# Altium schematic sheet [03] - SCHEMATIC.SchDoc
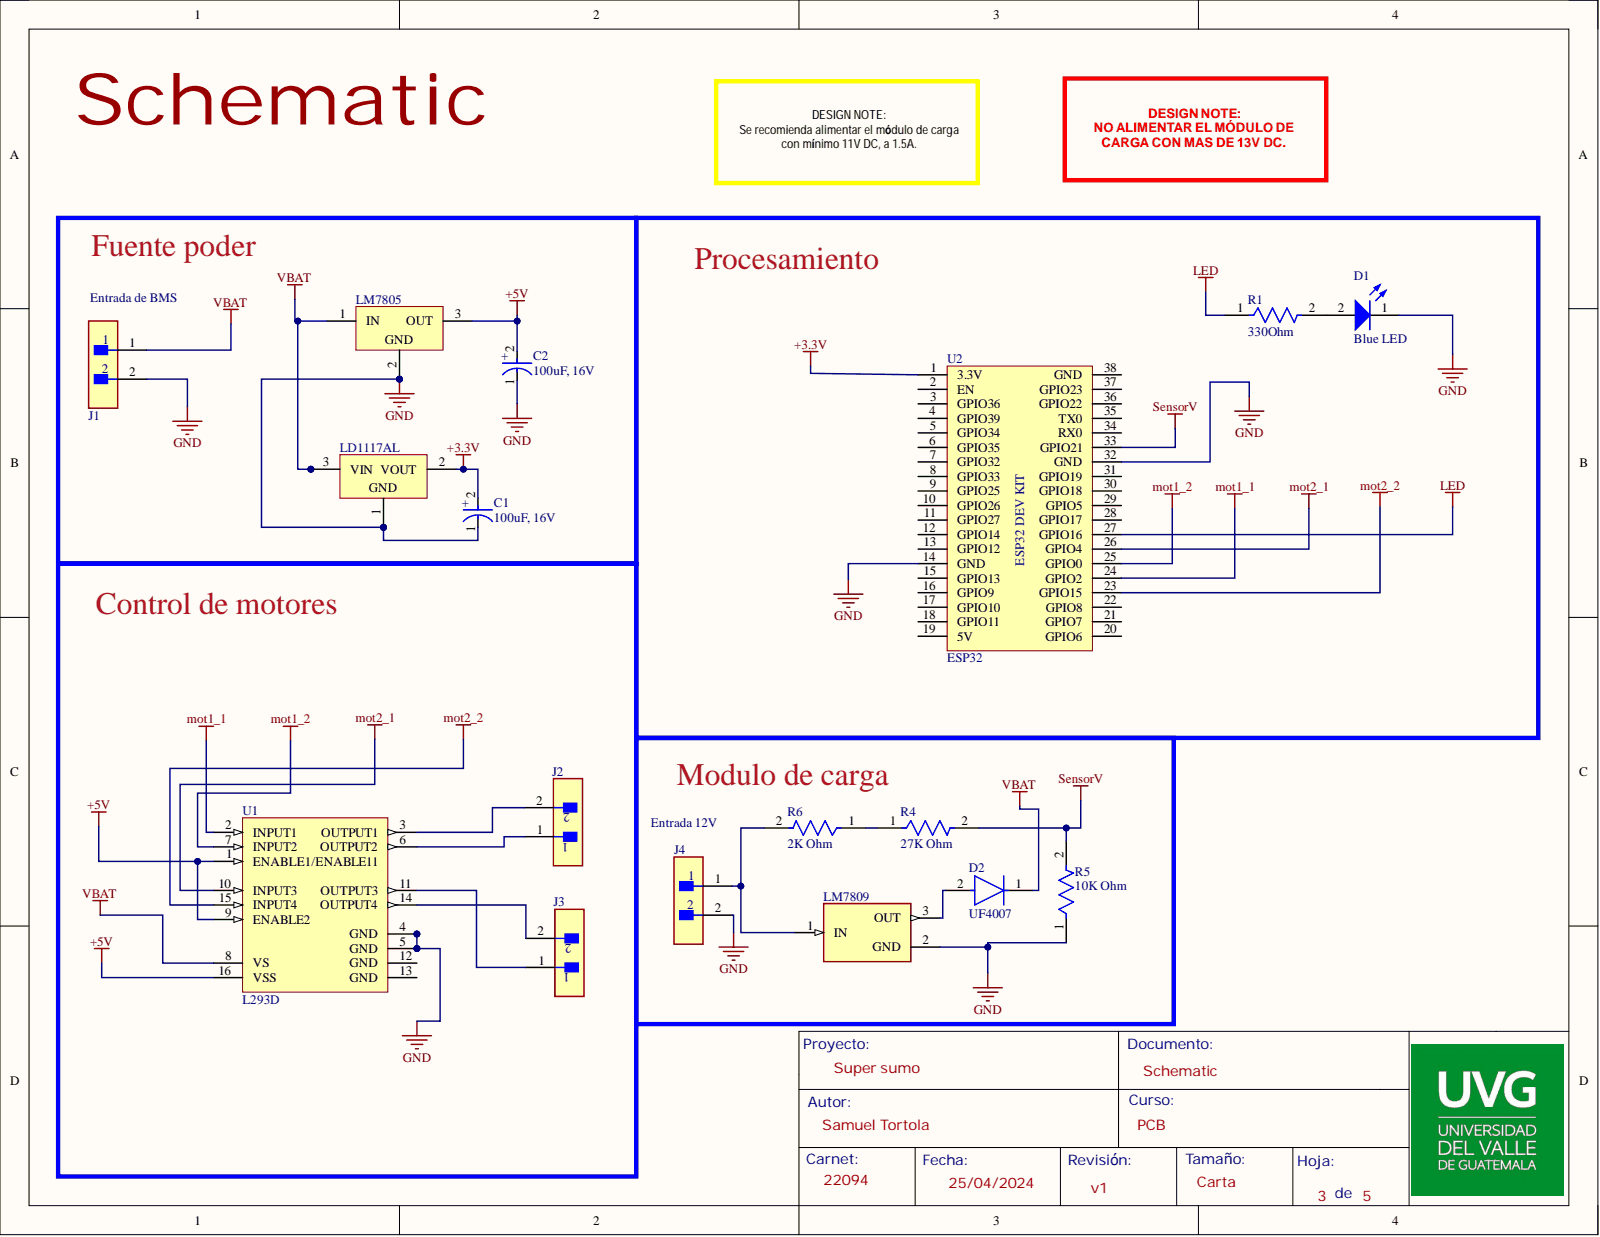
[diagram p0: [03] - SCHEMATIC.SchDoc]

## Parts
C1  100uF, 16V  [FP-RAD-TH-D_6_3_0_5-L_7_1-MFG]
C2  100uF, 16V  [FP-RAD-TH-D_6_3_0_5-L_7_1-MFG]
D1  Blue LED  Color: Blue  [WL-TMRW_D3.9H10.5]
D2  UF4007  [FP-017AH-MFG]
J1  B2B-EH-A(LF)(SN)  [FP-B2B-EH-A_LF_SN-MFG]  (value not drawn)
J2  B2B-EH-A(LF)(SN)  [FP-B2B-EH-A_LF_SN-MFG]  (value not drawn)
J3  B2B-EH-A(LF)(SN)  [FP-B2B-EH-A_LF_SN-MFG]  (value not drawn)
J4  B2B-EH-A(LF)(SN)  [FP-B2B-EH-A_LF_SN-MFG]  (value not drawn)
LD1117AL  LD1117V33C  [TO220]  (value not drawn)
LM7805  LM7805  [TO220]
LM7809  LM7809CT  [TO254P470X1016X1879-3P]  (value not drawn)
R1  330Ohm  [FP-MRS25-MFG]
R4  27K Ohm  [FP-MRS25-MFG]
R5  10K Ohm  [FP-MRS25-MFG]
R6  2K Ohm  [FP-MRS25-MFG]
U1  L293D  [STM-L293D-16]
U2  ESP32  [ESP32 DEV KIT]

## Nets
+3.3V: C1.2 LD1117AL.2 U2.1(3.3V)
+5V: C2.2 LM7805.3 U1.1(ENABLE1/ENABLE11) U1.9(ENABLE2) U1.16(VSS)
GND: C1.1 C2.1 D1.1 J1.2 J4.2 LD1117AL.1 LM7805.2 LM7809.2 R5.1 U1.4(GND) U1.5(GND) U2.14(GND) U2.32(GND)
LED: R1.1 U2.27(GPIO16)
mot1_1: U1.2(INPUT1) U2.24(GPIO2)
mot1_2: U1.7(INPUT2) U2.25(GPIO0)
mot2_1: U1.10(INPUT3) U2.26(GPIO4)
mot2_2: U1.15(INPUT4) U2.23(GPIO15)
SensorV: R4.2 R5.2 U2.33(GPIO21)
VBAT: D2.1 J1.1 LD1117AL.3 LM7805.1 U1.8(VS)
NetD1_2: D1.2 R1.2
NetD2_2: D2.2 LM7809.3
NetJ2_1: J2.1 U1.6(OUTPUT2)
NetJ2_2: J2.2 U1.3(OUTPUT1)
NetJ3_1: J3.1 U1.11(OUTPUT3)
NetJ3_2: J3.2 U1.14(OUTPUT4)
NetJ4_1: J4.1 LM7809.1 R6.2
NetR4_1: R4.1 R6.1

Not connected: U1 12(GND) 13(GND); U2 2(EN) 3(GPIO36) 4(GPIO39) 5(GPIO34) 6(GPIO35) 7(GPIO32) 8(GPIO33) 9(GPIO25) 10(GPIO26) 11(GPIO27) 12(GPIO14) 13(GPIO12) 15(GPIO13) 16(GPIO9) 17(GPIO10) 18(GPIO11) 19(5V) 20(GPIO6) 21(GPIO7) 22(GPIO8) 28(GPIO17) 29(GPIO5) 30(GPIO18) 31(GPIO19) 34(RX0) 35(TX0) 36(GPIO22) 37(GPIO23) 38(GND)

## Library data of the parts
C1 C2 = CMP-05427-001316-1: Aluminum Electrolytic Capacitors (Radial Lead Type) 100uF ±20% 16V; Lead Diameter: 0.45mm; Life (Hours): 1000Hour; Polarity: Polar; Ripple Current: 91mA; Capacitance: 100uF; Case/Package: Radial; Component Kind: Standard; Diameter: 6.3mm; Height: 7mm; Height - Seated (Max): 0.315inch; Lead Pitch: 2.5mm; Length: 7mm; Library Name: Altium Content Vault; Max Operating Temperature: 85°C; Min Operating Temperature: -40°C; Mount: Through Hole; Package Quantity: 2000; Packaging: Tape and Reel; Radiation Hardening: No; RoHS Compliant: Yes; Series: KA; Termination: Radial; Tolerance: 20%; Voltage: 16V; Voltage Rating: 16V; Voltage Rating (DC): 16V
D1 = CMP-1489-00002-2: NexarPartId: 29717808; Dominant Wavelength: 470nm; Forward Current: 100mA; Forward Voltage: 2.8V; Lens Size: 3mm; Lens Style: Circular, Clear, Round; Luminous Intensity: 3.8cd; Max Reverse Voltage (DC): 5V; Peak Wavelength: 465nm; Test Current: 20mA; Viewing Angle: 30°; Case/Package: Radial; Component Kind: Standard; Height: 5.3mm; Length: 3mm; Library Name: Altium Content Vault; Max Operating Temperature: 85°C; Min Operating Temperature: -40°C; Mount: Through Hole; Packaging: Bulk; REACH SVHC: Unknown; RoHS: Compliant; Wavelength: 470nm; Width: 3mm
D2 = CMP-2000-05028-2: DIODE GEN PURP 1KV 1A DO41; Manufacturer: ON Semiconductor; Capacitance: 17pF; Max Junction Temp: +150°C; Max Repetitive Reverse Voltage: 1000V; Max Surge Forward Current: 30A; Peak Reverse Current: 10uA; Value: 17pF; Automotive: No; Category: Diode; Component Kind: Standard; ComponentLink2Description: Datasheet; ComponentLink2URL: https://www.onsemi.com/pub/Collateral/UF4007-D.pdf; Device Class L1: Discrete Semiconductors; Device Class L2: Diodes; Device Class L3: Rectifier Diodes; Digikey Description: DIODE GEN PURP 1KV 1A DO41; Footprint Url: https://www.onsemi.com/pub/Collateral/017AH.PDF; Forward Current: 1A; Height: 2.72mm; Lead Free: Yes; Library Name: Altium Content Vault; Manufacturer Part Number: UF4007; Mouser Description: Rectifiers 1000V 1a Rectifier Glass Passivated; Octopart: https://octopart.com/uf4007-on+semiconductor-84331693; Package: 017AH; RoHS: TRUE; Temperature: -65°C to +150°C; Temperature Range High: +150°C; Temperature Range Low: -65°C
J1-J4 = CMP-17439-000026-1: CONN HEADER VERT 2POS 2.5MM; Connector Type: Plug; Contact Resistance: 20mR; Current Rating: 3A; Gender: Male; Max Voltage Rating (AC): 250V; Number of Contacts: 2; Color: Natural; Component Kind: Standard; Contact Material: Copper Alloy; Contact Mating Length: 0.13inch; Contact Plating: Tin; Contact Type: Male Pin; Depth: 3.8mm; Fastening Type: Detent; Housing Color: White; Housing Material: Nylon; Lead Free: Lead Free; Length: 7.5mm; Library Name: Altium Content Vault; Max Current Rating: 3A; Max Operating Temperature: 85°C; Min Operating Temperature: -25°C; Mount: Through Hole; Number of Positions: 2; Number of Rows: 1; Orientation: Straight; Packaging: Bulk; Pitch: 2.5mm; Series: EH; Termination: Press-Fit; Voltage Rating: 250V
LD1117AL = CMP-0244-00471-1: Fixed Low Drop Positive Voltage Regulator, 3.3V, 3-Pin TO-220; Component Kind: Standard; ComponentLink1Description: Manufacturer URL; ComponentLink1URL: http://www.st.com; ComponentLink2Description: Datasheet; ComponentLink2URL: http://www.st.com/internet/com/TECHNICAL_RESOURCES/TECHNICAL_LITERATURE/DATASHEET/CD00000544.pdf; ComponentLink3Description: Package Specification; ComponentLink3URL: http://www.st.com/internet/com/TECHNICAL_RESOURCES/TECHNICAL_LITERATURE/PACKAGE_INFORMATION/CD00004649.pdf; DatasheetVersion: 1/11/2011; Library Name: Altium Content Vault; PackageDescription: TO, Thru-Hole, Vertical, Heatsink Mounted; 3 In-Line Leads; Pitch 2.54 mm; PackageReference: TO220; PackageVersion: ver3.0
LM7805 = LM7805: Positive Voltage Regulator, 5V, 3-Pin TO-220; Component Kind: Standard; ComponentLink1Description: Manufacturer URL; ComponentLink1URL: http://www.st.com; ComponentLink2Description: Datasheet; ComponentLink2URL: http://www.st.com/internet/com/TECHNICAL_RESOURCES/TECHNICAL_LITERATURE/DATASHEET/CD00000444.pdf; ComponentLink3Description: Package Specification; ComponentLink3URL: http://www.st.com/internet/com/TECHNICAL_RESOURCES/TECHNICAL_LITERATURE/PACKAGE_INFORMATION/CD00004649.pdf; DatasheetVersion: 16/11/2011; Library Name: LM7805.IntLib; PackageDescription: TO, Thru-Hole, Vertical, Heatsink Mounted; 3 In-Line Leads; Pitch 2.54 mm; PackageReference: TO220; PackageVersion: ver3.0
LM7809 = LM7809CT: Linear Voltage Regulator IC 1 Output 1A TO-220-3; MF: Fujitsu; Availability: Unavailable; Component Kind: Standard; Library Name: LM7809CT.IntLib; MP: LM7809C; Package: TO-220-3 Fujitsu; Price: None; SnapEDA_Link: https://www.snapeda.com/parts/LM7809C/Fujitsu/view-part/1233224/?ref=snap
R1 R4-R6 = CMP-02408-000049-1: RES 953 OHM 0.6W 1% AXIAL; Lead Length: 26mm; Max Power Dissipation: 600mW; Resistance: 953R; Temperature Coefficient: 50ppm/°C; Voltage Rating: 350V; Case/Package: Axial; Component Kind: Standard; Construction: Cylindrical; Contact Plating: Tin; Diameter: 2.5mm; Lead Free: Lead Free; Length: 6.5mm; Library Name: Altium Content Vault; Max Operating Temperature: 155°C; Military Standard: Not; Min Operating Temperature: -55°C; Number of Terminations: 2; Packaging: Tape and Reel; Power Rating: 600mW; Radiation Hardening: No; Resistance Tolerance: 1%; Resistor Type: Metal Film; RoHS Compliant: Yes; Series: MRS25; Technology: THIN FILM; Termination: Axial; Tolerance: 1%; Width: 2.5mm
U1 = CMP-2000-06524-1: Push-Pull Four Channel Driver with Diode, 4.5 to 36 V, -40 to 150 degC, 16-Pin PDIP, RoHS, Tube; Manufacturer: STMicroelectronics; Current - Output / Channel: 600mA; Current - Peak Output: 1.2A; Dynamic Catalog: HalfBridge; Input Type: Non-Inverting; Number of Outputs: 4; Other Names: 497-2936-5; Other Related Documents: L293DViewAllSpecifications; Voltage - Supply: 4.5V~36V; Category: IntegratedCircuits(ICs); Component Kind: Standard; Datasheet URL: http://www.st.com/st-web-ui/static/active/en/resource/technical/document/datasheet/CD00000059.pdf; Datasheet Version: 07/2003; Family: PMIC-MOSFET,BridgeDrivers-InternalSwitch; Library Name: Altium Content Vault; Manufacturer URL: http://www.st.com/; Mounting Technology: ThroughHole; Mounting Type: ThroughHole; On-State Resistance: -; Operating Temperature: -40°C~150°C; Package / Case: 16-DIP(0.300",7.62mm); Package Description: 16-Pin Thru-Hole Dual-In-Line, Body 20 x 6.85 mm, Pitch 2.54 mm; Package Reference: DIP-16; Packaging: Tube; PartNumber: L293D; Series: -; Standard Package: 25; Supplier Device Package: 16-PowerDIP; Type: HalfBridge
U2 = ESP32 Dev Module Kit: ESP32 Depelopment version 38 pines; Component Kind: Standard; Library Name: ESP32.IntLib
